# Altium schematic sheet EAP_DD_CO_Fixture.SchDoc(EAP_DD_CO_Fixture)
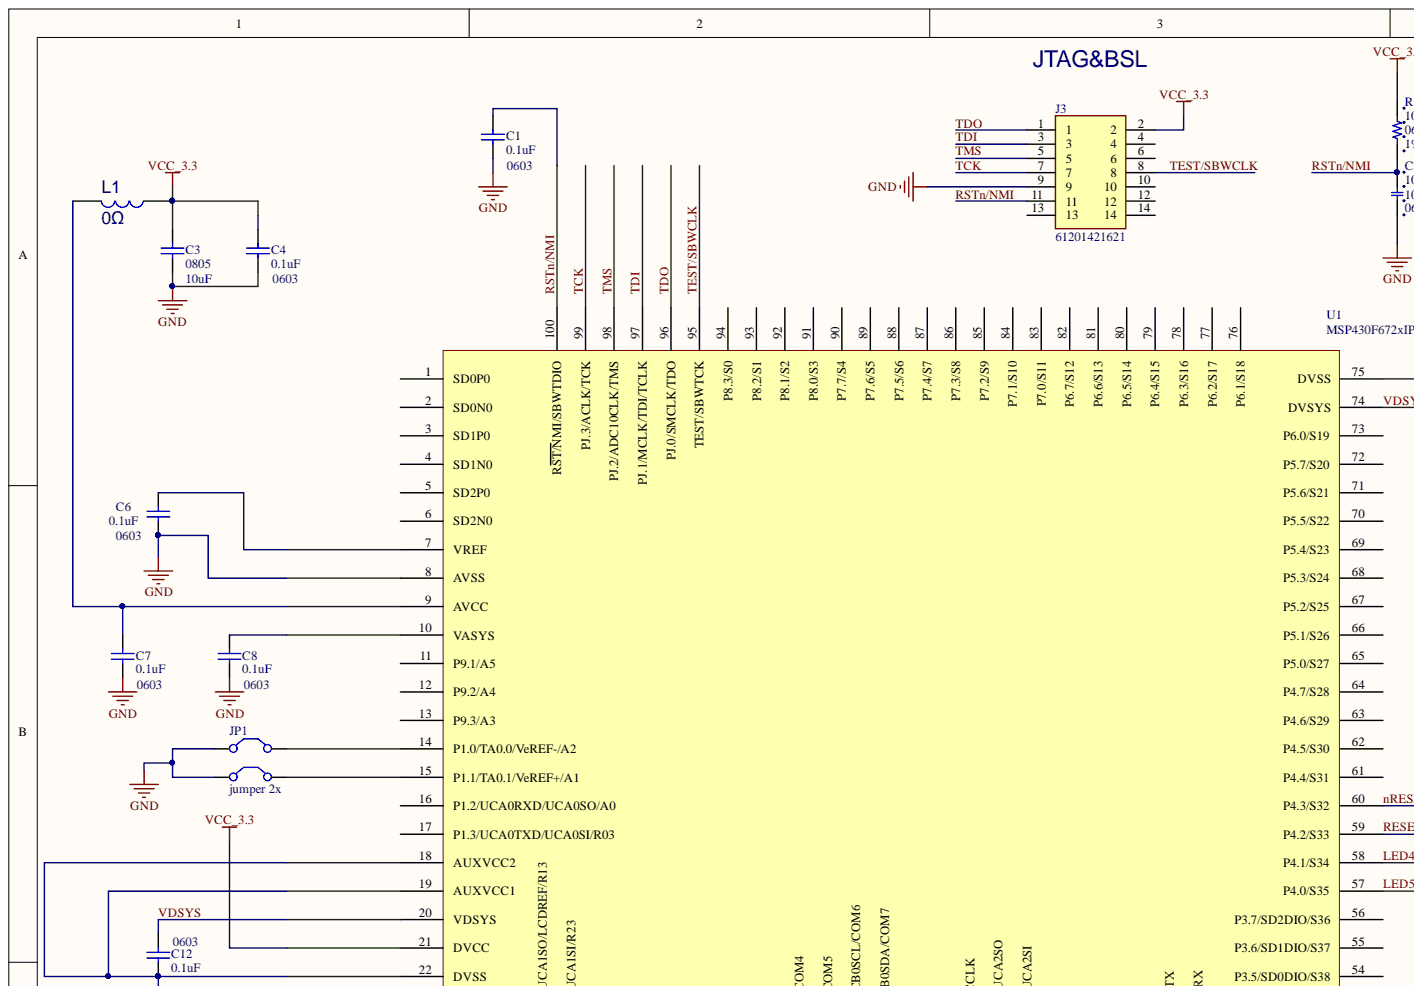
[diagram p0: EAP_DD_CO_Fixture.SchDoc, top left]
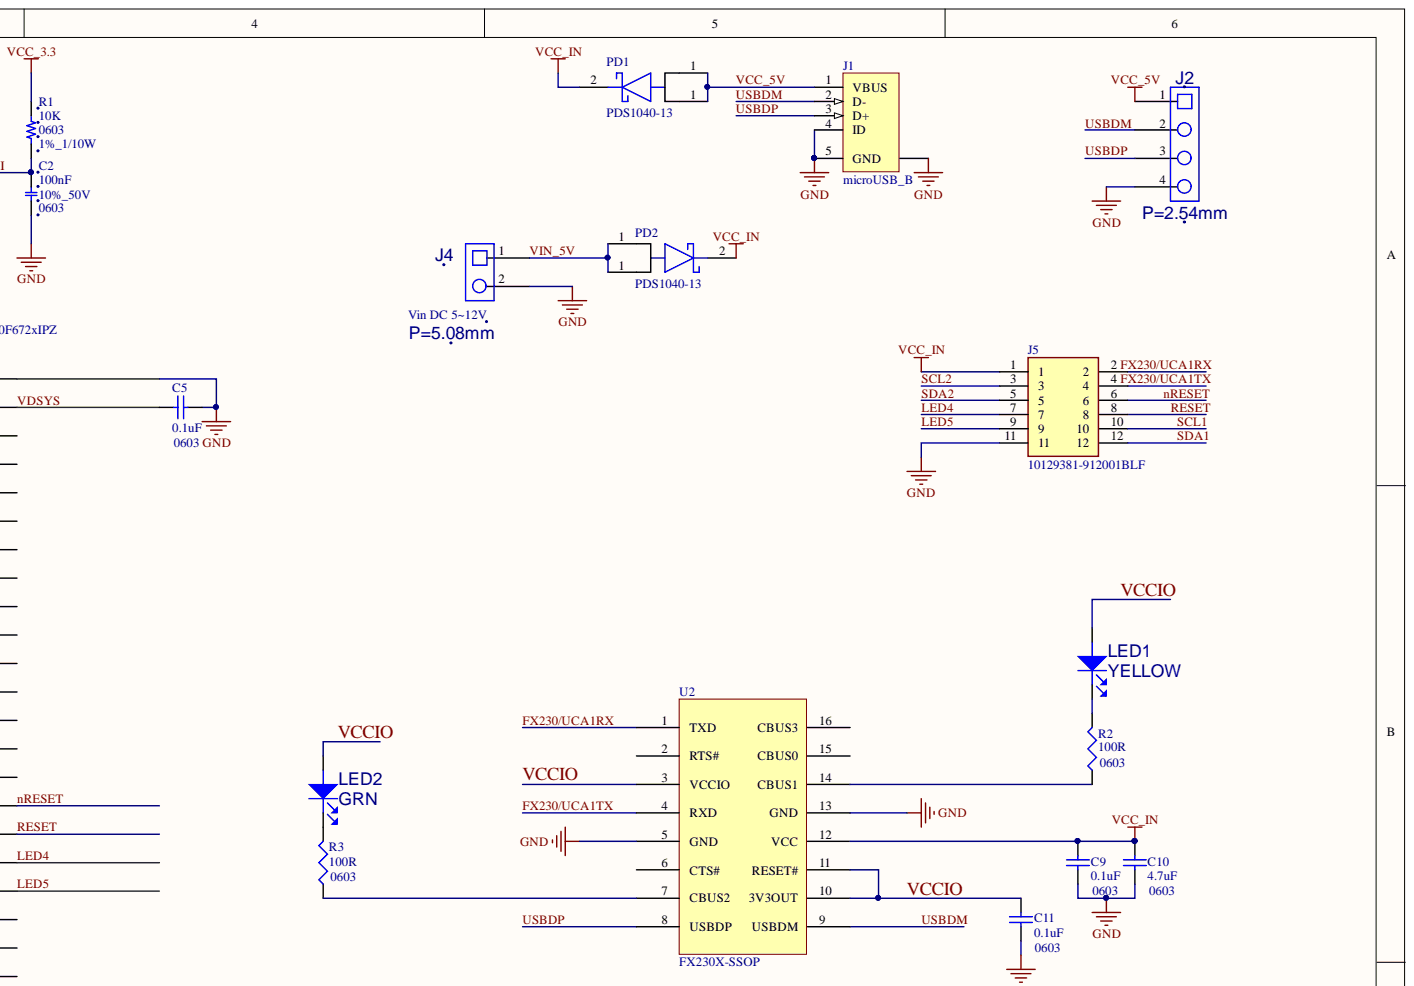
[diagram p1: EAP_DD_CO_Fixture.SchDoc, top right]
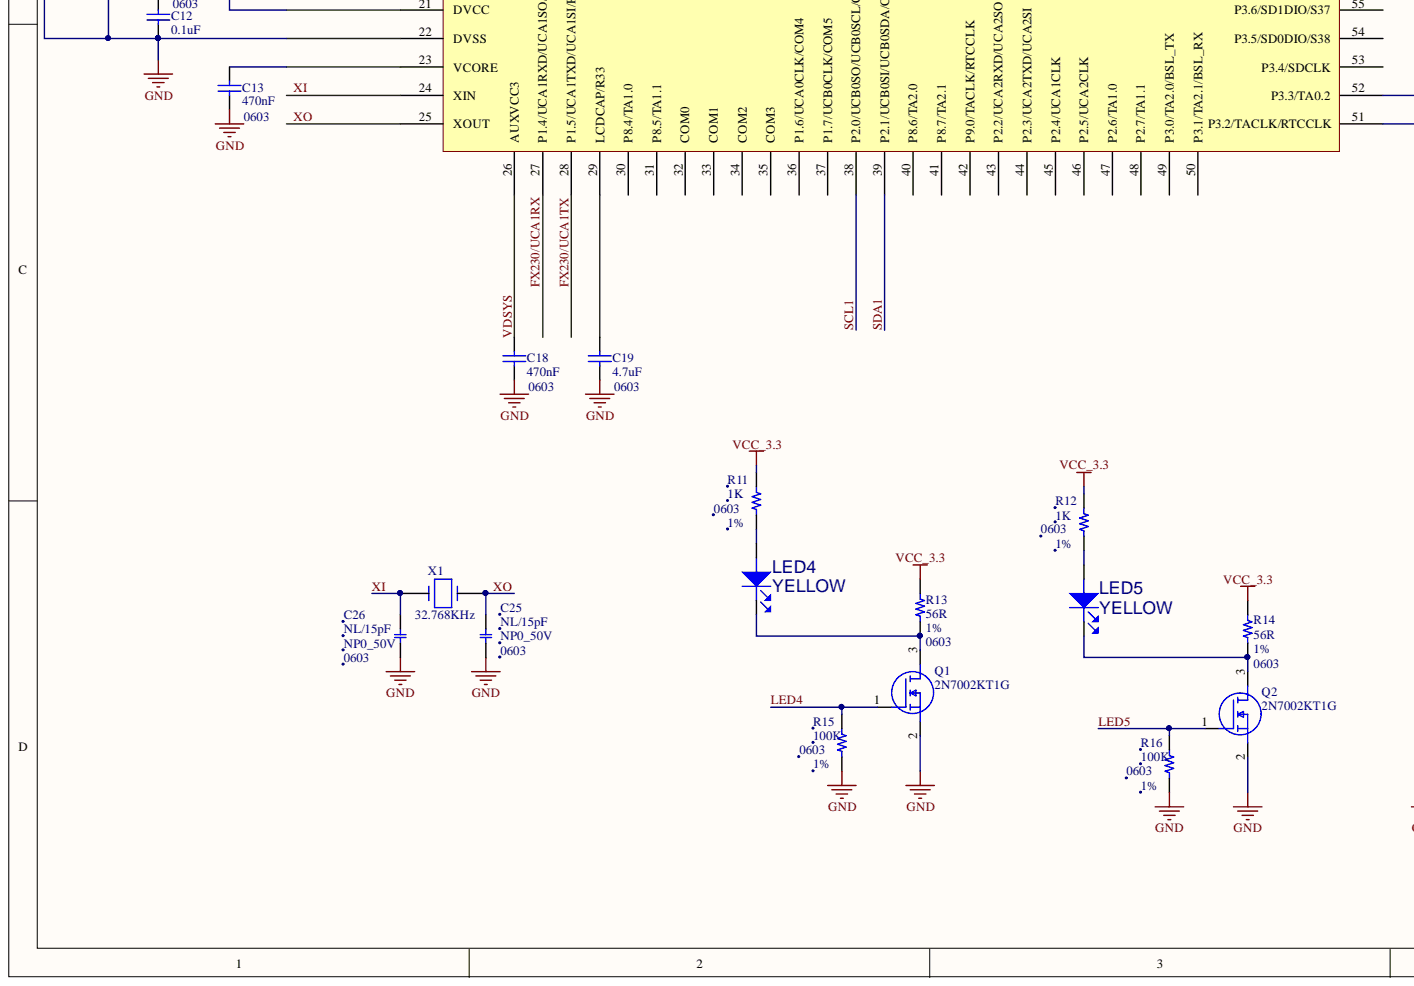
[diagram p2: EAP_DD_CO_Fixture.SchDoc, bottom left]
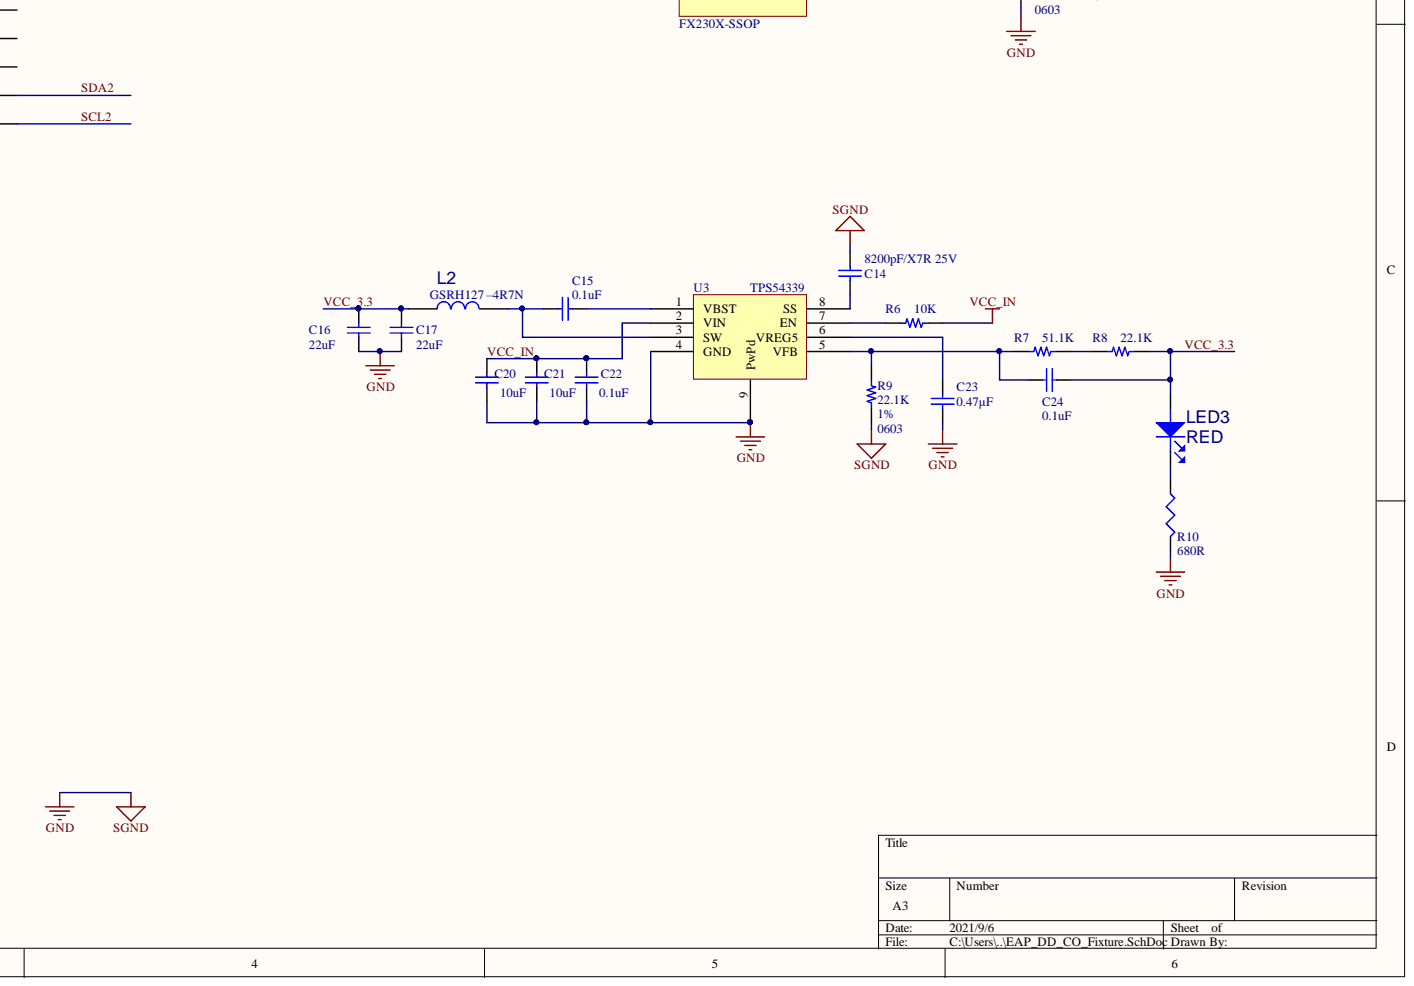
[diagram p3: EAP_DD_CO_Fixture.SchDoc, bottom right]

## Parts
C1  0603  value 0.1uF  [CC0603]
C2  100nF  Characteristic: 10%_50V  Package: 0603  [CC0603]
C3  0805  value 10uF  [CC0805]
C4  0603  value 0.1uF  [CC0603]
C5  0603  value 0.1uF  [CC0603]
C6  0603  value 0.1uF  [CC0603]
C7  0603  value 0.1uF  [CC0603]
C8  0603  value 0.1uF  [CC0603]
C9  0603  value 0.1uF  [CC0603]
C10  0603  value 4.7uF  [CC0603]
C11  0603  value 0.1uF  [CC0603]
C12  0603  value 0.1uF  [CC0603]
C13  0603  value 470nF  [CC0603]
C14  8200pF/X7R 25V  [CC0603]
C15  value 0.1uF  [CC0603]  (hidden comment: Cap Semi)
C16  value 22uF  [CC0805]  (hidden comment: 22μF/X5R 10V)
C17  value 22uF  [CC0805]  (hidden comment: 22μF/X5R  10V)
C18  0603  value 470nF  [CC0603]
C19  0603  value 4.7uF  [CC0603]
C20  value 10uF  [CC0805]  (hidden comment: 10μF/X5R 25V)
C21  value 10uF  [CC0805]  (hidden comment: 10μF/X5R 25V)
C22  value 0.1uF  [CC0603]  (hidden comment: 0.1μF/X5R 25V)
C23  value 0.47μF  [CC0603]  (hidden comment: 0.47μF/X7R 16V)
C24  value 0.1uF  [CC0603]  (hidden comment: Cap Semi)
C25  NL/15pF  Characteristic: NP0_50V  Package: 0603  [CC0603]
C26  NL/15pF  Characteristic: NP0_50V  Package: 0603  [CC0603]
J1  microUSB_B  [MicroUSB - B]
J2  value P=2.54mm  [S-4P]  (hidden comment: PN1X04HM_P2.54)
J3  61201421621  [RHDR14W64P254_2X7_2544X910X885P]
J4  Vin DC 5~12V  value P=5.08mm  [KRM2]
J5  10129381-912001BLF  [HDRV12W90P254_2X6_1524X483X858P]
JP1  jumper 2x  [HDR2X2]
L1  value 0Ω  [CB0805]  (hidden comment: BEAD)
L2  GSRH127 –4R7N  [GSRH127]
LED1  YELLOW  [LED_0603]
LED2  GRN  [LED_0603]
LED3  RED  [LED_0603]
LED4  YELLOW  [LED_0603]
LED5  YELLOW  [LED_0603]
PD1  PDS1040-13  [PDS1040L]
PD2  PDS1040-13  [PDS1040L]
Q1  2N7002KT1G  [SOT23]
Q2  2N7002KT1G  [SOT23]
R1  10K  Characteristic: 1%_1/10W  Package: 0603  [CR0603]
R2  0603  value 100R  [CR0603]
R3  0603  value 100R  [CR0603]
R6  10K  [CR0603]
R7  51.1K  [CR0603]
R8  22.1K  [CR0603]
R9  22.1K  Characteristic: 1%  Package: 0603  [CR0603]
R10  value 680R  [CR0603]  (hidden comment: 0603)
R11  1K  Characteristic: 1%  Package: 0603  [CR0603]
R12  1K  Characteristic: 1%  Package: 0603  [CR0603]
R13  56R  Characteristic: 1%  Package: 0603  [CR0603]
R14  56R  Characteristic: 1%  Package: 0603  [CR0603]
R15  100K  Characteristic: 1%  Package: 0603  [CR0603]
R16  100K  Characteristic: 1%  Package: 0603  [CR0603]
U1  MSP430F672xIPZ  [S-PQFP-100]
U2  FX230X-SSOP  [SSOP16]
U3  TPS54339  [SOIC-8_POWERPAD]
X1  32.768KHz  [XTAL-1206]

## Nets
GND: C1.2 C2.2 C3.2 C4.2 C5.2 C6.2 C7.2 C8.2 C9.2 C10.2 C11.2 C12.2 C13.2 C14.1 C16.2 C17.2 C18.2 C19.2 C20.2 C21.2 C22.2 C23.2 C25.2 C26.2 J1.0 J1.4(ID) J1.5(GND) J2.4 J3.9 J4.2 J5.11 JP1.1 JP1.3 Q1.2 Q2.2 R9.2 R10.2 R15.1 R16.1 U1.8(AVSS) U1.18(AUXVCC2) U1.19(AUXVCC1) U1.22(DVSS) U1.75(DVSS) U2.5(GND) U2.13(GND) U3.4(GND) U3.9(PwPd)
FX230/UCA1RX: U1.27(P1.4/UCA1RXD/UCA1SO/LCDREF/R13) U2.1(TXD)
FX230/UCA1TX: U1.28(P1.5/UCA1TXD/UCA1SI/R23) U2.4(RXD)
LED4: J5.7 Q1.1 R15.2 U1.58(P4.1/S34)
LED5: J5.9 Q2.1 R16.2 U1.57(P4.0/S35)
NRESET: J5.6 U1.60(P4.3/S32)
RESET: J5.8 U1.59(P4.2/S33)
RSTN/NMI: C1.1 C2.1 J3.11 R1.2 U1.100(RST/NMI/SBWTDIO)
SCL1: J5.10 U1.38(P2.0/UCB0SO/UCB0SCL/COM6)
SCL2: J5.3 U1.51(P3.2/TACLK/RTCCLK)
SDA1: J5.12 U1.39(P2.1/UCB0SI/UCB0SDA/COM7)
SDA2: J5.5 U1.52(P3.3/TA0.2)
TCK: J3.7 U1.99(PJ.3/ACLK/TCK)
TDI: J3.3 U1.97(PJ.1/MCLK/TDI/TCLK)
TDO: J3.1 U1.96(PJ.0/SMCLK/TDO)
TEST/SBWCLK: J3.8 U1.95(TEST/SBWTCK)
TMS: J3.5 U1.98(PJ.2/ADC10CLK/TMS)
USBDM: J1.2(D-) J2.2 U2.9(USBDM)
USBDP: J1.3(D+) J2.3 U2.8(USBDP)
VCC_3.3: C3.1 C4.1 C16.1 C17.1 C24.2 J3.2 L1.1 L2.1 LED3.1 R1.1 R8.1 R11.2 R12.2 R13.2 R14.2 U1.21(DVCC)
VCC_5V: J1.1(VBUS) J2.1 PD1.1
VCC_IN: C9.1 C10.1 C20.1 C21.1 C22.1 J5.1 PD1.2 PD2.2 R6.1 U2.12(VCC) U3.2(VIN)
VCCIO: C11.1 LED1.1 LED2.1 U2.3(VCCIO) U2.10(3V3OUT) U2.11(RESET#)
VDSYS: C5.1 C12.1 C18.1 U1.20(VDSYS) U1.26(AUXVCC3) U1.74(DVSYS)
VIN_5V: J4.1 PD2.1
XI: C26.1 U1.24(XIN) X1.1
XO: C25.1 U1.25(XOUT) X1.2
NetC6_1: C6.1 U1.7(VREF)
NetC7_1: C7.1 L1.2 U1.9(AVCC)
NetC8_1: C8.1 U1.10(VASYS)
NetC13_1: C13.1 U1.23(VCORE)
NetC14_2: C14.2 U3.8(SS)
NetC15_1: C15.1 L2.2 U3.3(SW)
NetC15_2: C15.2 U3.1(VBST)
NetC19_1: C19.1 U1.29(LCDCAP/R33)
NetC23_1: C23.1 U3.6(VREG5)
NetC24_1: C24.1 R7.2 R9.1 U3.5(VFB)
NetJP1_2: JP1.2 U1.14(P1.0/TA0.0/VeREF-/A2)
NetJP1_4: JP1.4 U1.15(P1.1/TA0.1/VeREF+/A1)
NetLED1_2: LED1.2 R2.2
NetLED2_2: LED2.2 R3.2
NetLED3_2: LED3.2 R10.1
NetLED4_1: LED4.1 R11.1
NetLED4_2: LED4.2 Q1.3 R13.1
NetLED5_1: LED5.1 R12.1
NetLED5_2: LED5.2 Q2.3 R14.1
NetR2_1: R2.1 U2.14(CBUS1)
NetR3_1: R3.1 U2.7(CBUS2)
NetR6_2: R6.2 U3.7(EN)
NetR7_1: R7.1 R8.2

Not connected: J3 4 6 10 12 13 14; J5 2 4; U1 1(SD0P0) 2(SD0N0) 3(SD1P0) 4(SD1N0) 5(SD2P0) 6(SD2N0) 11(P9.1/A5) 12(P9.2/A4) 13(P9.3/A3) 16(P1.2/UCA0RXD/UCA0SO/A0) 17(P1.3/UCA0TXD/UCA0SI/R03) 30(P8.4/TA1.0) 31(P8.5/TA1.1) 32(COM0) 33(COM1) 34(COM2) 35(COM3) 36(P1.6/UCA0CLK/COM4) 37(P1.7/UCB0CLK/COM5) 40(P8.6/TA2.0) 41(P8.7/TA2.1) 42(P9.0/TACLK/RTCCLK) 43(P2.2/UCA2RXD/UCA2SO) 44(P2.3/UCA2TXD/UCA2SI) 45(P2.4/UCA1CLK) 46(P2.5/UCA2CLK) 47(P2.6/TA1.0) 48(P2.7/TA1.1) 49(P3.0/TA2.0/BSL_TX) 50(P3.1/TA2.1/BSL_RX) 53(P3.4/SDCLK) 54(P3.5/SD0DIO/S38) 55(P3.6/SD1DIO/S37) 56(P3.7/SD2DIO/S36) 61(P4.4/S31) 62(P4.5/S30) 63(P4.6/S29) 64(P4.7/S28) 65(P5.0/S27) 66(P5.1/S26) 67(P5.2/S25) 68(P5.3/S24) 69(P5.4/S23) 70(P5.5/S22) 71(P5.6/S21) 72(P5.7/S20) 73(P6.0/S19) 76(P6.1/S18) 77(P6.2/S17) 78(P6.3/S16) 79(P6.4/S15) 80(P6.5/S14) 81(P6.6/S13) 82(P6.7/S12) 83(P7.0/S11) 84(P7.1/S10) 85(P7.2/S9) 86(P7.3/S8) 87(P7.4/S7) 88(P7.5/S6) 89(P7.6/S5) 90(P7.7/S4) 91(P8.0/S3) 92(P8.1/S2) 93(P8.2/S1) 94(P8.3/S0); U2 2(RTS#) 6(CTS#) 15(CBUS0) 16(CBUS3)

## Library data of the parts
C1 C3-C13 C15-C24 = Cap Semi: Capacitor (Semiconductor SIM Model); Component Kind: Standard; Published: 8-Jun-2000; Revision: July-2002: Re-released for DXP Platform.; Signal Integrity: Cap Semi; Simulation: CAP; Publisher: Altium Limited
  C1 C3-C8 C12 C13 C18 C19: Library Name: CO2_RevA.SCHLIB
  C9-C11 C15-C17 C20-C24: Library Name: AECL_FAE.SCHLIB
C2 C25 C26 = ChipCap: MLCC; AVL_Part: AVL; Manufacture: 華新科技股份有限公司(Walsin Technology Corporation)||台灣東電化股份有限公司(TDK Taiwan Corporation)||台灣太陽誘電(股)公司(Taiwan  Taiyo Yuden  Co., Ltd.); Manufacture_Part_Number: 0603X105K250CT||C1608X5R1E105KT||TMK107BJ105KA; Part_Number: 1100007912; CLASS: DISCRETE; ProcessType: SMT; Tolerance: 10%; Component Kind: Standard; Library Name: ES_passive.SCHLIB
C14 = Cap Semi: Capacitor (Semiconductor SIM Model); Value: 8200pF; Component Kind: Standard; Published: 8-Jun-2000; Revision: July-2002: Re-released for DXP Platform.; Signal Integrity: Cap Semi; Simulation: CAP; Library Name: AECL_FAE.SCHLIB; Publisher: Altium Limited
J1 = microUSB_B: USB 2.0, SMT, B Type, Receptacle, 5 Position; ContactMaterial: Copper Alloy; ContactPlating: Gold (30); DrillSize: Corresponds to nominal value.; Note: Directive 2000/53/EC (ELV) Compliant; PanelGround: Without; PCB_Layout: Complies with manufacturer's recommendation.; Component Kind: Standard; ComponentLink1Description: Manufacturer Link; ComponentLink1URL: http://www.tycoelectronics.com/; ComponentLink2Description: Packaging Specification; ComponentLink2URL: http://ecommas.tycoelectronics.com/commerce/DocumentDelivery/DDEController?Action=showdoc&DocId=Customer+Drawing%7F440247%7FD%7Fpdf%7FEnglish%7FC_440247_D.pdf; DatasheetDocument: 27-Jun-2003; HousingMaterial: Thermoplastic; PackageDocument: 27-Jun-2003; PackageReference: 440247; Published: 15-Jul-2004; Signal Integrity: USB2.0; Library Name: AECL_SGX_sensors.SCHLIB; Publisher: Altium Limited
J2 = PN1X04HM_P2.54: PN1x04,180度,P=2.54mm; 料號: CN6-V1P04-000; Component Kind: Standard; Library Name: AECL_ODM_EPA.SCHLIB
J3 = 61201421621: Connector; Manufacturer_Name: Wurth Elektronik; Component Kind: Standard; Datasheet Link: https://componentsearchengine.com/Datasheets/2/61201421621.pdf; Height: 8.85mm; Manufacturer_Part_Number: 61201421621; Mouser Part Number: 710-61201421621; Mouser Price/Stock: https://www.mouser.co.uk/ProductDetail/Wurth-Elektronik/61201421621?qs=PhR8RmCirEYw8YsJCWHDPw%3D%3D
J4 = PN1X02HM_P5.08_1: PN1x02,180度,P=5.08mm; Component Kind: Standard; Library Name: AECL_Tooling.SCHLIB
J5 = 10129381-912001BLF: Connector; Manufacturer_Name: Amphenol; Arrow Part Number: 10129381-912001BLF; Arrow Price/Stock: https://www.arrow.com/en/products/10129381-912001blf/amphenol-fci; Component Kind: Standard; Datasheet Link: https://cdn.amphenol-icc.com/media/wysiwyg/files/drawing/10129381.pdf; Height: 8.58mm; Manufacturer_Part_Number: 10129381-912001BLF; Mouser Part Number: 649-1012938191201BLF; Mouser Price/Stock: https://www.mouser.co.uk/ProductDetail/Amphenol-FCI/10129381-912001BLF/?qs=0lQeLiL1qybH5JyuikDxjQ%3D%3D; Library Name: EAP_DD_CO_Fixture.SCHLIB
L2 = Inductor: Component Kind: Standard; Description: Inductor; Library Name: AECL_ODM_EPA.SCHLIB
LED1-LED5 = LED: Component Kind: Standard; Description: LED; Value: LED
  LED1 LED2: Library Name: AECL_FAE.SCHLIB
  LED3-LED5: Library Name: AECL_LoRa.SCHLIB
PD1 PD2 = PDS1040-13: Diode; Manufacturer_Name: Diodes Inc.; Arrow Part Number: PDS1040-13; Arrow Price/Stock: https://www.arrow.com/en/products/pds1040-13/diodes-incorporated; Component Kind: Standard; Datasheet Link: https://www.diodes.com/assets/Datasheets/Ds30538.pdf; Height: 1mm; Library Name: SamacSys.SchLib; Manufacturer_Part_Number: PDS1040-13; Mouser Part Number: 621-PDS1040-13; Mouser Price/Stock: https://www.mouser.co.uk/ProductDetail/Diodes-Incorporated/PDS1040-13?qs=JV7lzlMm3yIIoUGcW9e7Dg%3D%3D
Q1 Q2 = 2N7002KT1G: MOSFET (N-Channel); Manufacturer_Name: ON Semiconductor; Arrow Part Number: 2N7002KT1G; Arrow Price/Stock: https://www.arrow.com/en/products/2n7002kt1g/on-semiconductor; Component Kind: Standard; Datasheet Link: http://www.onsemi.com/pub/Collateral/2N7002K-D.PDF; Height: 1.11mm; Manufacturer_Part_Number: 2N7002KT1G; Mouser Part Number: 863-2N7002KT1G; Mouser Price/Stock: https://www.mouser.co.uk/ProductDetail/ON-Semiconductor/2N7002KT1G?qs=Jh7l0IbPjifUiTytu%252BGGDQ%3D%3D; Library Name: EAP_DD_CO_Fixture.SCHLIB
R1 R6-R8 = ChipRes: Chip Resistor; AVL_Part: AVL; Manufacture: 厚聲電子工業有限公司(Uniroyal Electronics Industry Co.,Ltd.)||國巨股份有限公司(Yageo Co.,Ltd.)||大毅科技股份有限公司(Ta-I Technolongy Co., Ltd.); Manufacture_Part_Number: 0603WAF2200T5E||RC0603FR-07220RL||RM06FTN2200; Part_Number: 105A522003; CLASS: DISCRETE; ProcessType: SMT; Tolerance: 1%; Component Kind: Standard; Library Name: ES_passive.SCHLIB
R2 R3 R10 = Res3: Resistor; Component Kind: Standard; Published: 8-Jun-2000; Revision: July-2002: Re-released for DXP Platform.; Signal Integrity: Res; Simulation: RESISTOR; Library Name: AECL_FAE.SCHLIB; Publisher: Altium Limited
R9 R11-R16 = ChipRes: Chip Resistor; AVL_Part: AVL; Manufacture: 厚聲電子工業有限公司(Uniroyal Electronics Industry Co.,Ltd.)||國巨股份有限公司(Yageo Co.,Ltd.)||大毅科技股份有限公司(Ta-I Technolongy Co., Ltd.); Manufacture_Part_Number: 0603WAF2200T5E||RC0603FR-07220RL||RM06FTN2200; Part_Number: 105A522003; CLASS: DISCRETE; ProcessType: SMT; Component Kind: Standard; Library Name: ES_passive.SCHLIB; Tolerance: 1%
X1 = XTAL-1: XTAL, 32.768KHz 1206, 12.5pF; ERP_Serial: 3DUS-009678-000R; Component Kind: Standard; Library Name: ES_passive.SCHLIB
JP1 = jumper 2x: Component Kind: Standard; Library Name: AECL_CO.SCHLIB
L1 = BEAD: Component Kind: Standard; Description: BEAD; Library Name: Relay-L.SchLib
U1 = MSP430F672xIPZ: Component Kind: Standard; Library Name: CO2_RevA.SCHLIB
U2 = FX230X-SSOP: Component Kind: Standard; Library Name: AECL_FAE.SCHLIB
U3 = TPS54339: Component Kind: Standard; Library Name: TPS54339DDA.SchLib
